# Altium schematic sheet PPG.SchDoc
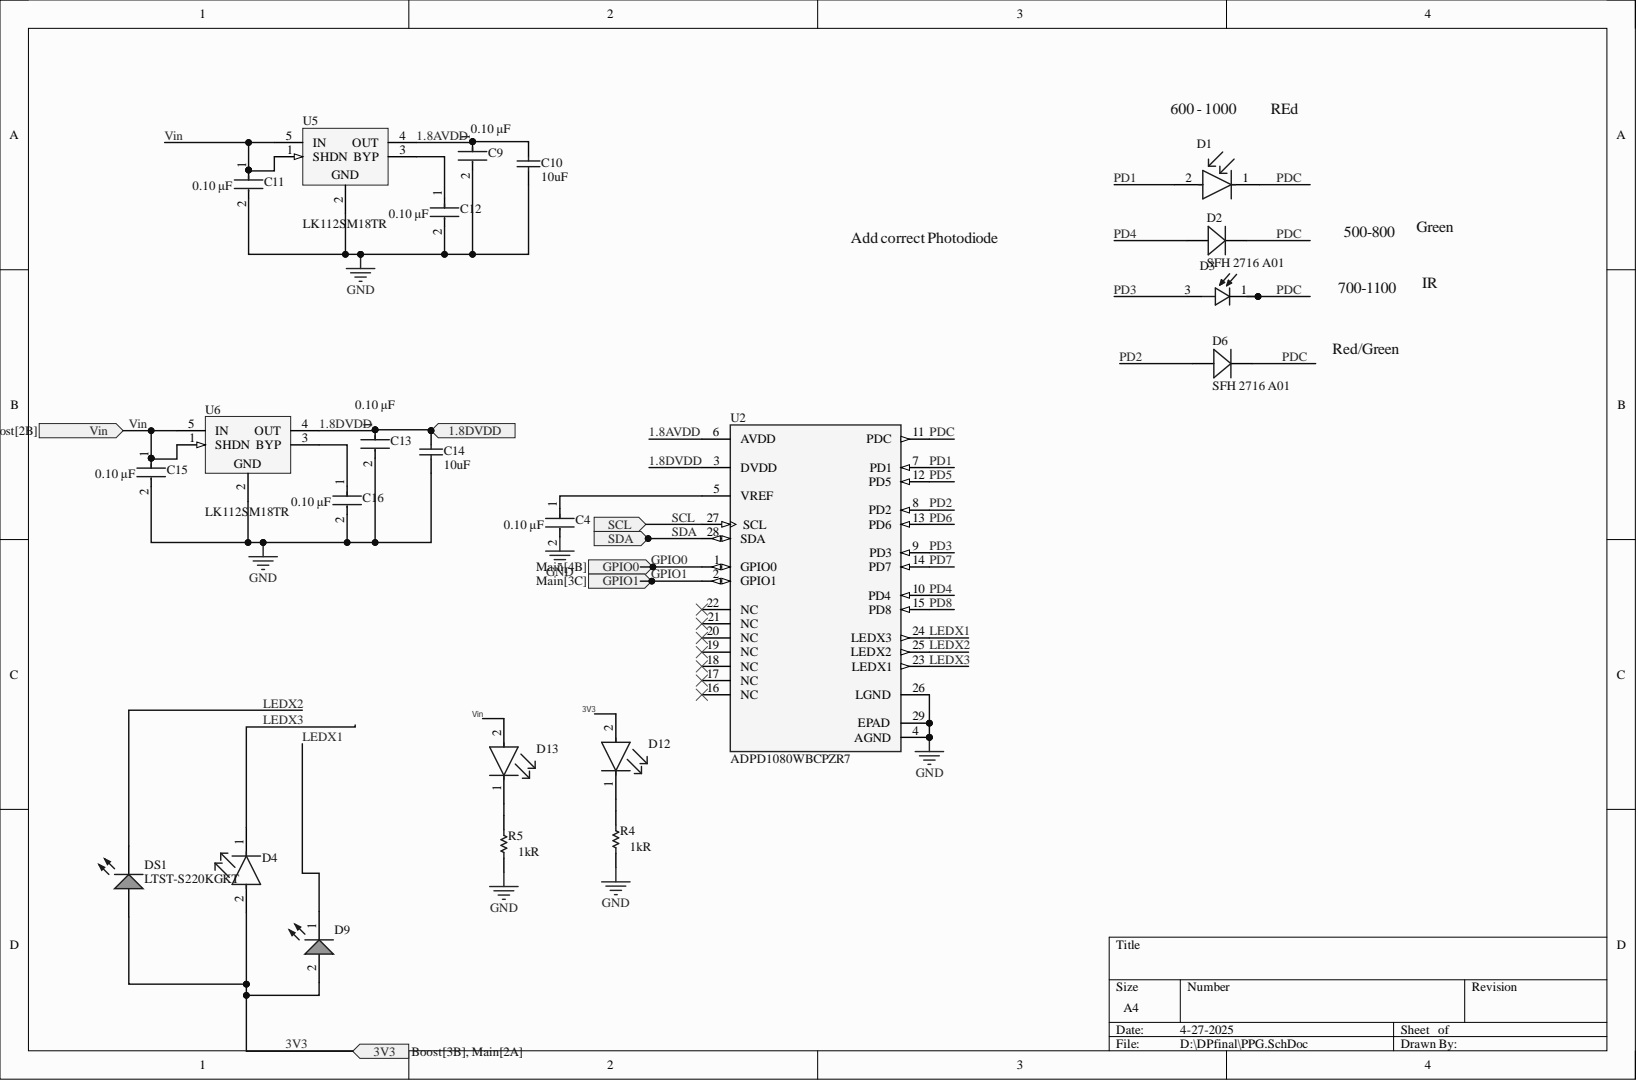
[diagram p0: PPG.SchDoc]

## Parts
C4  value 0.10 μF  Capacitance: 0.10 μF  [FP-C0402-BB-MFG]  (hidden comment: C0402C104K3RACTU)
C9  value 0.10 μF  Capacitance: 0.10 μF  [FP-C0402-BB-MFG]  (hidden comment: C0402C104K3RACTU)
C10  value 10uF  (hidden comment: Capacitor)
C11  value 0.10 μF  Capacitance: 0.10 μF  [FP-C0402-BB-MFG]  (hidden comment: C0402C104K3RACTU)
C12  value 0.10 μF  [FP-C0402-BB-MFG]  (hidden comment: C0402C104K3RACTU)
C13  value 0.10 μF  Capacitance: 0.10 μF  [FP-C0402-BB-MFG]  (hidden comment: C0402C104K3RACTU)
C14  value 10uF  (hidden comment: Capacitor)
C15  value 0.10 μF  Capacitance: 0.10 μF  [FP-C0402-BB-MFG]  (hidden comment: C0402C104K3RACTU)
C16  value 0.10 μF  [FP-C0402-BB-MFG]  (hidden comment: C0402C104K3RACTU)
D1  SFH 2704  [FP-SFH_2704-MFG]  (value not drawn)
D2  SFH 2716 A01  [SFH 2716 A01]
D3  SFH 2400 FA  [SFH 2400 FA]  (value not drawn)
D4  LS R976-NR-1  [FP-LS_R976-MFG]  (value not drawn)
D6  SFH 2716 A01  [SFH 2716 A01]
D9  150141GS73100  [WL-SMTW_3528]  (value not drawn)
D12  LTST-S270KRKT  [FP-LTST-S270KRKT-MFG]  (value not drawn)
D13  LTST-S270KRKT  [FP-LTST-S270KRKT-MFG]  (value not drawn)
DS1  LTST-S220KGKT  [LTST-S220KGKT_V]
R4  value 1kR  [RESC1005X40X25LL10T10]  (hidden comment: RK73H1ETTP1001F)
R5  value 1kR  [RESC1005X40X25LL10T10]  (hidden comment: RK73H1ETTP1001F)
U2  ADPD1080WBCPZR7  [ADPD1080WBCPZR7]
U5  LK112SM18TR  [SOT23-5L_M]
U6  LK112SM18TR  [SOT23-5L_M]

## Ports
1.8DVDD, 3V3, GPIO0, GPIO1, SCL, SDA, Vin

## Nets
GND: C4.2 C9.2 C10.1 C11.2 C12.2 C13.2 C14.1 C15.2 C16.2 R4.1 R5.1 U2.4(AGND) U2.26(LGND) U2.29(EPAD) U5.2 U6.2
1.8AVDD: C9.1 C10.2 U2.6(AVDD) U5.4(OUT)
1.8DVDD: C13.1 C14.2 U2.3(DVDD) U6.4(OUT) ; port 1.8DVDD
3V3: D4.2 D9.2 D12.2 DS1.1 ; port 3V3
GPIO0: U2.1(GPIO0) ; port GPIO0
GPIO1: U2.2(GPIO1) ; port GPIO1
LEDX1: D9.1 U2.24(LEDX3)
LEDX2: DS1.2 U2.25(LEDX2)
LEDX3: D4.1 U2.23(LEDX1)
PD1: D1.2 U2.7(PD1)
PD2: D6.A U2.8(PD2)
PD3: D3.3 U2.9(PD3)
PD4: D2.A U2.10(PD4)
PD5: U2.12(PD5)
PD6: U2.13(PD6)
PD7: U2.14(PD7)
PD8: U2.15(PD8)
PDC: D1.1 D2.K D3.1 D6.K U2.11(PDC)
SCL: U2.27(SCL) ; port SCL
SDA: U2.28(SDA) ; port SDA
Vin: C11.1 C15.1 D13.2 U5.1(SHDN) U5.5(IN) U6.1(SHDN) U6.5(IN) ; port Vin
NetC4_1: C4.1 U2.5(VREF)
NetC12_1: C12.1 U5.3(BYP)
NetC16_1: C16.1 U6.3(BYP)
NetD12_1: D12.1 R4.2
NetD13_1: D13.1 R5.2

Not connected: U2 16(NC) 17(NC) 18(NC) 19(NC) 20(NC) 21(NC) 22(NC)

## Library data of the parts
C4 C9 C11 C13 C15 = CMP-03020-012858-1: Cap Ceramic 0.1uF 25V X7R 10% Pad SMD 0402 125C T/R; Manufacturer: Kemet; Dielectric Material: 4241ConductorMaterial; Temperature Coefficient: 15%; Tolerance: 0.1; Automotive: No; Case Code (Imperial): 0402; Case Code (Metric): 1005; Case/Package: 0402; Category: Cap; Component Kind: Standard; ComponentLink1Description: http://www.farnell.com/datasheets/2746129.pdf?src-supplier=Farnell; ComponentLink1URL: http://www.farnell.com/datasheets/2746129.pdf?src-supplier=Farnell; ComponentLink2Description: Datasheet; ComponentLink2URL: https://content.kemet.com/datasheets/KEM_C1002_X7R_SMD.pdf; ComponentLink3Description: http://www.farnell.com/datasheets/2746129.pdf?src-supplier=Newark; ComponentLink3URL: http://www.farnell.com/datasheets/2746129.pdf?src-supplier=Newark; ComponentLink4Description: http://www.farnell.com/datasheets/2746129.pdf?src-supplier=Element14; ComponentLink4URL: http://www.farnell.com/datasheets/2746129.pdf?src-supplier=Element14; ComponentLink5Description: https://datasheet.octopart.com/C0402C104K3RACTU-Kemet-datasheet-62095741.pdf?src-supplier=Element14; ComponentLink5URL: https://datasheet.octopart.com/C0402C104K3RACTU-Kemet-datasheet-62095741.pdf?src-supplier=Element14; ComponentLink6Description: https://api.kemet.com/component-edge/download/datasheet/C0402C104K3RAC7867.pdf?src-supplier=Digikey; ComponentLink6URL: https://api.kemet.com/component-edge/download/datasheet/C0402C104K3RAC7867.pdf?src-supplier=Digikey; ComponentLink7Description: https://datasheet.octopart.com/C0402C104K3RACTU-KEMET-datasheet-176289272.pdf?src-supplier=Newark; ComponentLink7URL: https://datasheet.octopart.com/C0402C104K3RACTU-KEMET-datasheet-176289272.pdf?src-supplier=Newark; ComponentLink8Description: https://datasheet.octopart.com/C0402C104K3RACTU-KEMET-datasheet-157246124.pdf?src-supplier=Electro+Sonic; ComponentLink8URL: https://datasheet.octopart.com/C0402C104K3RACTU-KEMET-datasheet-157246124.pdf?src-supplier=Electro+Sonic; Device Class L1: Passive Components; Device Class L2: Capacitors; Device Class L3: Ceramic Capacitors; Dielectric: X7R; Digikey Description: Cap Ceramic 0.1uF 25V X7R 10% Pad SMD 0402 125C T/R; Height: 0.55mm; Ipc Land Pattern Name: CAPC10050X50; Lead Free: Yes; Library Name: Altium Content Vault; Manufacturer Part Number: C0402C104K3RACTU; Material: Ceramic; Max Operating Temperature: 125°C; Min Operating Temperature: -55°C; Mouser Description: Cap Ceramic 0.1uF 25V X7R 10% Pad SMD 0402 125C T/R; Octopart: https://octopart.com/c0402c104k3ractu-kemet-71105629; Package: C0402-BB; Packaging: Cut Tape; Pins: 2NUMBER; Pricing: 1=0.16, 10=0.095, 100=0.0611, 1000=0.04279, 2500=0.03794, 5000=0.0349 (USD); Reach SVHC Compliant: No; RoHS: TRUE; RoHS Compliant: Yes; Stock: 453452; Supplier: Digikey; Supplier Part Number: 399-14148-1-ND; Temperature: -55°C to +125°C; Temperature Characteristic: X7R; Temperature Range High: +125°C; Temperature Range Low: -55°C; Voltage Rating: 25 V; Voltage Rating (DC): 25V
C10 C14 = Capacitor: CL10 Series 0603 10 uF 16 V ±20% Tolerance X5R Multilayer Ceramic Chip Capacitor; Manufacturer: Samsung; Manufacturer Part Number: CL10A106MO8NQNC; SimulationGenericComponent: True; Category: Ceramic Capacitors; Component Kind: Standard; ComponentLink1Description: https://datasheet.ciiva.com/25711/cl10a106mo8nqnc-25711536.pdf?src-supplier=Digikey; ComponentLink1URL: https://datasheet.ciiva.com/25711/cl10a106mo8nqnc-25711536.pdf?src-supplier=Digikey; ComponentLink2Description: https://datasheet.ciiva.com/26838/getdatasheetpartid-746571-26838345.pdf?src-supplier=Verical; ComponentLink2URL: https://datasheet.ciiva.com/26838/getdatasheetpartid-746571-26838345.pdf?src-supplier=Verical; ComponentLink3Description: https://datasheet.ciiva.com/29198/457342-da-01-en-vs-kondensator-0603-29198787.pdf?src-supplier=Conrad; ComponentLink3URL: https://datasheet.ciiva.com/29198/457342-da-01-en-vs-kondensator-0603-29198787.pdf?src-supplier=Conrad; Lead Free: 5697LeadFree; Library Name: Simulation Generic Components.IntLib; Pricing: 1=0.3, 10=0.167, 50=0.167, 100=0.098, 1000=0.066, 10000=0.047 (USD); RoHS: Yes; Simulation: C_Capacitor_Default; Stock: 15386; Supplier: Mouser; Supplier Part Number: 187-CL10A106MO8NQNC
C12 C16 = CMP-03020-012858-1: Cap Ceramic 0.1uF 25V X7R 10% Pad SMD 0402 125C T/R; Manufacturer: Kemet; Capacitance: 0.10 μF; Dielectric Material: 4241ConductorMaterial; Temperature Coefficient: 15%; Tolerance: 0.1; Value: 0.10 μF; Automotive: No; Case Code (Imperial): 0402; Case Code (Metric): 1005; Case/Package: 0402; Category: Cap; Component Kind: Standard; ComponentLink1Description: http://www.farnell.com/datasheets/2746129.pdf?src-supplier=Farnell; ComponentLink1URL: http://www.farnell.com/datasheets/2746129.pdf?src-supplier=Farnell; ComponentLink2Description: Datasheet; ComponentLink2URL: https://content.kemet.com/datasheets/KEM_C1002_X7R_SMD.pdf; ComponentLink3Description: http://www.farnell.com/datasheets/2746129.pdf?src-supplier=Newark; ComponentLink3URL: http://www.farnell.com/datasheets/2746129.pdf?src-supplier=Newark; ComponentLink4Description: http://www.farnell.com/datasheets/2746129.pdf?src-supplier=Element14; ComponentLink4URL: http://www.farnell.com/datasheets/2746129.pdf?src-supplier=Element14; ComponentLink5Description: https://datasheet.octopart.com/C0402C104K3RACTU-Kemet-datasheet-62095741.pdf?src-supplier=Element14; ComponentLink5URL: https://datasheet.octopart.com/C0402C104K3RACTU-Kemet-datasheet-62095741.pdf?src-supplier=Element14; ComponentLink6Description: https://api.kemet.com/component-edge/download/datasheet/C0402C104K3RAC7867.pdf?src-supplier=Digikey; ComponentLink6URL: https://api.kemet.com/component-edge/download/datasheet/C0402C104K3RAC7867.pdf?src-supplier=Digikey; ComponentLink7Description: https://datasheet.octopart.com/C0402C104K3RACTU-KEMET-datasheet-176289272.pdf?src-supplier=Newark; ComponentLink7URL: https://datasheet.octopart.com/C0402C104K3RACTU-KEMET-datasheet-176289272.pdf?src-supplier=Newark; ComponentLink8Description: https://datasheet.octopart.com/C0402C104K3RACTU-KEMET-datasheet-157246124.pdf?src-supplier=Electro+Sonic; ComponentLink8URL: https://datasheet.octopart.com/C0402C104K3RACTU-KEMET-datasheet-157246124.pdf?src-supplier=Electro+Sonic; Device Class L1: Passive Components; Device Class L2: Capacitors; Device Class L3: Ceramic Capacitors; Dielectric: X7R; Digikey Description: Cap Ceramic 0.1uF 25V X7R 10% Pad SMD 0402 125C T/R; Height: 0.55mm; Ipc Land Pattern Name: CAPC10050X50; Lead Free: Yes; Library Name: Altium Content Vault; Manufacturer Part Number: C0402C104K3RACTU; Material: Ceramic; Max Operating Temperature: 125°C; Min Operating Temperature: -55°C; Mouser Description: Cap Ceramic 0.1uF 25V X7R 10% Pad SMD 0402 125C T/R; Octopart: https://octopart.com/c0402c104k3ractu-kemet-71105629; Package: C0402-BB; Packaging: Cut Tape; Pins: 2NUMBER; Pricing: 1=0.16, 10=0.095, 100=0.0611, 1000=0.04279, 2500=0.03794, 5000=0.0349 (USD); Reach SVHC Compliant: No; RoHS: TRUE; RoHS Compliant: Yes; Stock: 453452; Supplier: Digikey; Supplier Part Number: 399-14148-1-ND; Temperature: -55°C to +125°C; Temperature Characteristic: X7R; Temperature Range High: +125°C; Temperature Range Low: -55°C; Voltage Rating: 25 V; Voltage Rating (DC): 25V
D1 = CMP-62027-000006-1: SENSOR PHOTODIODE 900NM 2SMD; Manufacturer: Osram Opto; Dark Current: 100pA; Diode Type: PIN; Max Reverse Voltage (DC): 6V; Response Time: 67ns; Viewing Angle: 120°; Case/Package: SMD/SMT; Category: Photodiodes; Component Kind: Standard; ComponentLink1Description: https://media.digikey.com/pdf/Data%20Sheets/Osram%20PDFs/SFH_2704_v0.0_9-12-18.pdf?src-supplier=Digikey; ComponentLink1URL: https://media.digikey.com/pdf/Data%20Sheets/Osram%20PDFs/SFH_2704_v0.0_9-12-18.pdf?src-supplier=Digikey; ComponentLink2Description: http://datasheet.octopart.com/SFH-2704-Osram-Opto-datasheet-142374394.pdf?src-supplier=Rs; ComponentLink2URL: http://datasheet.octopart.com/SFH-2704-Osram-Opto-datasheet-142374394.pdf?src-supplier=Rs; Library Name: Altium Content Vault; Manufacturer Part Number: SFH 2704; Max Operating Temperature: 85°C; Min Operating Temperature: -40°C; Mounting Technology: Surface Mount; Packaging: Cut Tape; Pricing: 1=0.83, 10=0.576, 100=0.4218, 500=0.35302, 1000=0.33048 (USD); RoHS: Yes; RoHS Compliant: Yes; Series: CHipLED; Stock: 4566; Supplier: Digikey; Supplier Part Number: 475-SFH2704CT-ND; Wavelength: 900nm
D2 D6 = SFH 2716 A01: Silicon PIN Photodiode with Vλ Characteristics, -40 to 100 °C, 1.3 x 2 x 0.8 mm; Manufacturer: Osram Opto; Category: Phototransistor; Component Kind: Standard; Library Name: Schlib1.SchLib; Manufacturer Part Number: SFH 2716 A01; Pricing: 1=1.01, 10=0.615, 100=0.4162, 500=0.33804, 1000=0.31406 (USD); RoHS: Yes; Stock: 7067; Supplier: DigiKey; Supplier 1: Digikey; Supplier Part Number: 475-SFH2716A01CT-ND; Supplier Part Number 1: 475-SFH2716A01DKR-ND
D4 = CMP-62016-000037-1: LED RED DIFFUSED 0805 SMD; Dominant Wavelength: 633nm; Forward Current: 25mA; Forward Voltage: 2.5V; Lens Color: White; Lens Size: 1.25mm; Lens Style: Flat; Luminous Flux: 0.47lm; Luminous Intensity: 180mcd; Number of Elements: 1; Power Dissipation: 65W; Reverse Voltage: 12V; Test Current: 20mA; Viewing Angle: 160°; Case Code (Imperial): 0805; Case Code (Metric): 2012; Case/Package: 0805; Color: Red; Component Kind: Standard; Depth: 1.35mm; Height: 0.9mm; Illumination Color: Red; Length: 2mm; Lens Transparency: Diffused; Library Name: Altium Content Vault; Max Operating Temperature: 85°C; Min Operating Temperature: -30°C; Mount: Surface Mount; Number of LEDs: 1; Number of Pins: 2; Package Quantity: 16000; Packaging: Tape and Reel; Radiation Hardening: No; Width: 1.25mm
D9 = CMP-1429-00004-2: Manufacturer: Wurth Electronics; NexarPartId: 29717794; Color: Green; Dominant Wavelength: 525nm; Forward Current: 100mA; Forward Voltage: 3.1V; Lens Color: Colorless; Lens Size: 2.4mm; Lens Style: Clear, Round; Lens Transparency: Clear; Luminous Intensity: 1cd; Max Reverse Voltage (DC): 5V; Peak Wavelength: 515nm; Power Dissipation: 105mW; Test Current: 20mA; Viewing Angle: 120°; Case Code (Imperial): 1411; Case Code (Metric): 3528; Case/Package: 3528; Category: LEDs; Component Kind: Standard; ComponentLink1Description: https://datasheet.ciiva.com/23843/150141gs73100-23843266.pdf?src-supplier=Newark; ComponentLink1URL: https://datasheet.ciiva.com/23843/150141gs73100-23843266.pdf?src-supplier=Newark; ComponentLink2Description: https://datasheet.ciiva.com/29278/759463-da-01-en-smd-topled-einf-gruen-150141gs73100-29278953.pdf?src-supplier=Conrad; ComponentLink2URL: https://datasheet.ciiva.com/29278/759463-da-01-en-smd-topled-einf-gruen-150141gs73100-29278953.pdf?src-supplier=Conrad; ComponentLink3Description: https://datasheet.ciiva.com/22656/1911484-22656997.pdf?src-supplier=Element14; ComponentLink3URL: https://datasheet.ciiva.com/22656/1911484-22656997.pdf?src-supplier=Element14; ComponentLink4Description: https://datasheet.ciiva.com/48430/150141gs73100-eng-tds-48430060.pdf?src-supplier=Distrelec; ComponentLink4URL: https://datasheet.ciiva.com/48430/150141gs73100-eng-tds-48430060.pdf?src-supplier=Distrelec; ComponentLink5Description: https://datasheet.ciiva.com/29563/150141gs73100-29563029.pdf?src-supplier=Digikey; ComponentLink5URL: https://datasheet.ciiva.com/29563/150141gs73100-29563029.pdf?src-supplier=Digikey; ComponentLink6Description: https://datasheet.ciiva.com/29563/150141gs73100-29563030.pdf?src-supplier=Digikey; ComponentLink6URL: https://datasheet.ciiva.com/29563/150141gs73100-29563030.pdf?src-supplier=Digikey; Height: 1.9mm; Lead Free: Lead Free; Length: 3.5mm; Library Name: Altium Content Vault; Manufacturer Part Number: 150141GS73100; Max Operating Temperature: 85°C; Min Operating Temperature: -40°C; Mount: Surface Mount; Mounting Technology: Surface Mount; Package Quantity: 1; Packaging: Tape and Reel; Pricing: 1=0.17, 50=0.1684, 100=0.1467, 500=0.115 (USD); REACH SVHC: Unknown; Reach SVHC Compliant: Unknown; Reverse Voltage: 5V; RoHS: Compliant; RoHS Compliant: Yes; Series: WL-SMTW; Stock: 36143; Supplier: Digikey; Supplier Part Number: 732-4997-1-ND; Wavelength: 525nm; Width: 2.8mm
D12 D13 = CMP-2000-06630-2: LED RED CLEAR CHIP SMD R/A; Manufacturer: Vishay Lite-On; Dominant Wavelength: 631nm; Forward Current: 30mA; Forward Voltage: 2V; Lens Color: Colorless; Lens Size: 0.6mm; Lens Style: Diffused; Luminous Intensity: 54mcd; Max Power Dissipation: 75mW; Number of Elements: 1; Reverse Voltage: 5V; Test Current: 20mA; Viewing Angle: 130°; Wavelength: 639nm; Case Code (Imperial): 0603; Case Code (Metric): 1608; Case/Package: 0603; Category: LEDs; Color: Red; Component Kind: Standard; ComponentLink1Description: https://datasheet.ciiva.com/11775/0900766b80dc0b8e-11775445.pdf?src-supplier=Rs; ComponentLink1URL: https://datasheet.ciiva.com/11775/0900766b80dc0b8e-11775445.pdf?src-supplier=Rs; ComponentLink2Description: https://datasheet.ciiva.com/12400/ltst-s270krkt-201849-12400833.pdf?src-supplier=Mouser; ComponentLink2URL: https://datasheet.ciiva.com/12400/ltst-s270krkt-201849-12400833.pdf?src-supplier=Mouser; ComponentLink3Description: https://datasheet.ciiva.com/2110/ltst-s270krkt-2110021.pdf?src-supplier=Digikey; ComponentLink3URL: https://datasheet.ciiva.com/2110/ltst-s270krkt-2110021.pdf?src-supplier=Digikey; ComponentLink4Description: https://datasheet.ciiva.com/16387/p-100-ltst-s270krkt-16387507.pdf?src-supplier=Future+Electronics; ComponentLink4URL: https://datasheet.ciiva.com/16387/p-100-ltst-s270krkt-16387507.pdf?src-supplier=Future+Electronics; ComponentLink5Description: https://datasheet.ciiva.com/2110/s-110-ltst-s270krkt-2110023.pdf?src-supplier=Digikey; ComponentLink5URL: https://datasheet.ciiva.com/2110/s-110-ltst-s270krkt-2110023.pdf?src-supplier=Digikey; ComponentLink6Description: https://datasheet.ciiva.com/2110/s-110-ltst-s270krkt-2110022.pdf?src-supplier=Digikey; ComponentLink6URL: https://datasheet.ciiva.com/2110/s-110-ltst-s270krkt-2110022.pdf?src-supplier=Digikey; Depth: 0.6mm; Height: 0.6mm; Illumination Color: Red; Lead Free: Lead Free; Length: 1.6mm; Lens Transparency: Clear; Library Name: Altium Content Vault; Manufacturer Part Number: LTST-S270KRKT; Max Operating Temperature: 85°C; Min Operating Temperature: -55°C; Mount: Surface Mount; Mounting Technology: Surface Mount; Number of LEDs: 1; Number of Pins: 2; Package Quantity: 1; Packaging: Tape and Reel; Pins: 2; Power Dissipation: 75mW; Power Rating: 75mW; Pricing: 1=0.24, 10=0.133, 100=0.0617, 500=0.05696, 1000=0.04462, 2000=0.04367 (USD); Radiation Hardening: No; RoHS: Yes; RoHS Compliant: Yes; Series: -; Stock: 83540; Supplier: Digikey; Supplier Part Number: 160-1479-1-ND; Width: 1.15mm
DS1 = CMP-2000-06628-1: LED Green, 75 mW, 30 mA, -30 to 85 degC, 2-Pin SMD, RoHS, Tape and Reel; Manufacturer: Lite-On; Chip Material: AlGaInP; DELETED: Compliant; Dominant Wave Length: 571nm; Emitting Color: YellowGreen; Forward Current: 30mA; Forward Voltage: 2.4V; High Brightness: Yes; Lum Intensity: 35mcd; Main Category: ChipLED; Number of  Elements: 1; Peak Wavelength: 574nm; Power Dissipation: 75W; Product Depth (mm): 0.6mm; Product Height (mm): 1mm; Product Length (mm): 2.1mm; Rad Hardened: No; Reverse Voltage: 5V; Shape Type: Rectangular; Test Current (It): 20mA; Type: Uni-Color; Viewing Angle: 130deg; Component Kind: Standard; Datasheet URL: http://optoelectronics.liteon.com/upload/download/DS22-2000-075/S_110_LTST-S220KGKT.pdf; Datasheet Version: Rev. D, 12/2013; Lens Dimensions: 1.7x0.6x0.5mm; Library Name: Altium Content Vault; Luminous Intensity: 35mcd; Manufacturer URL: http://www.us.liteon.com/; Mounting: SurfaceMount; Mounting Technology: SurfaceMount; Operating Temp Range: -55Cto85C; Operating Temperature Classification: Industrial; Package Description: 2-Pin Surface Mount Device, Body 2.15 x 0.6 mm; Package Reference: S220KGKT; Package Type: ChipLed; Packaging: TapeandReel; Pin Count: 2
R4 R5 = CMP-2002-03808-1: Manufacturer: KOA Speer; Max Power Dissipation: 100mW; Power Rating: 63mW; Temperature Coefficient: 100ppm/°C; Tolerance: 1%; Voltage Rating: 50V; Case Code (Imperial): 0402; Case Code (Metric): 1005; Case/Package: 0402; Category: Chip SMD Resistors; Component Kind: Standard; ComponentLink1Description: https://datasheet.ciiva.com/5554/rk73h-5554172.pdf?src-supplier=Mouser; ComponentLink1URL: https://datasheet.ciiva.com/5554/rk73h-5554172.pdf?src-supplier=Mouser; Construction: Rectangular; Depth: 0.5mm; Features: Moisture Resistant,Automotive AEC-Q200; Height: 0.35mm; Lead Free: 5697LeadFree; Length: 1mm; Library Name: Altium Content Vault; Manufacturer Part Number: RK73H1ETTP1001F; Max Operating Temperature: 155°C; Military Standard: Not; Min Operating Temperature: -55°C; Mounting Technology: Surface Mount; Number of Terminations: 2; Package Quantity: 10000; Packaging: Tape and Reel; Part Number: RK73H1ETTP1001F; Pricing: 1=0.1, 10=0.021, 50=0.0134, 100=0.0112, 500=0.00764, 1000=0.00658, 5000=0.00478 (USD); Ratings: AEC-Q200; RoHS: Yes; RoHS Compliant: Yes; Series: RK73H; Stock: 1021606; Supplier: Digikey; Supplier Part Number: 2019-RK73H1ETTP1001FCT-ND; Technology: THICK FILM; Termination: SMD/SMT; Voltage Rating (DC): 50V; Width: 0.5mm
U2 = ADPD1080WBCPZR7: Optical Afe For Health Monitorin; Component Kind: Standard; Library Name: Schlib1.SchLib; Supplier 1: Digikey; Supplier Part Number 1: 505-ADPD1080WBCPZR7CT-ND
U5 U6 = CMP-0244-00332-1: 200mA, Low Noise Low Drop Voltage Regulator with Shutdown Function, 1.8V, 5-Pin SOT23-5L, Tape and Reel; Manufacturer: STMicroelectronics; Category: Voltage Regulators - Linear; Component Kind: Standard; ComponentLink1Description: Manufacturer URL; ComponentLink1URL: http://www.st.com; ComponentLink2Description: Datasheet; ComponentLink2URL: http://www.st.com/internet/com/TECHNICAL_RESOURCES/TECHNICAL_LITERATURE/DATASHEET/CD00002558.pdf; ComponentLink3Description: https://datasheet.ciiva.com/3225/cd00002558-3225210.pdf?src-supplier=Avnet; ComponentLink3URL: https://datasheet.ciiva.com/3225/cd00002558-3225210.pdf?src-supplier=Avnet; ComponentLink4Description: https://datasheet.ciiva.com/2042/cd00002558-2042967.pdf?src-supplier=Digikey; ComponentLink4URL: https://datasheet.ciiva.com/2042/cd00002558-2042967.pdf?src-supplier=Digikey; ComponentLink5Description: https://datasheet.ciiva.com/2042/cd00002558-2042968.pdf?src-supplier=Digikey; ComponentLink5URL: https://datasheet.ciiva.com/2042/cd00002558-2042968.pdf?src-supplier=Digikey; ComponentLink6Description: https://datasheet.ciiva.com/2042/cd00002558-2042969.pdf?src-supplier=Digikey; ComponentLink6URL: https://datasheet.ciiva.com/2042/cd00002558-2042969.pdf?src-supplier=Digikey; DatasheetVersion: 10/07/2008; Library Name: Altium Content Vault; Manufacturer Part Number: LK112SM18TR; PackageDescription: 5-Pin SOT-23 Package, 2.9 x 1.6 mm Body, 0.95 mm Pitch, 2.8 mm Lead Span; PackageReference: SOT23-5L; PackageVersion: 1/01/2008; Pricing: 1=0.91, 10=0.653, 25=0.588, 100=0.5172, 250=0.4834, 500=0.46302, 1000=0.44623 (USD); RoHS: Yes; Stock: 1322; Supplier: Digikey; Supplier Part Number: 497-7811-1-ND
D3 = SFH 2400 FA: Component Kind: Standard; Library Name: Schlib1.SchLib
